# Altium schematic sheet temperatureSensor.SchDoc
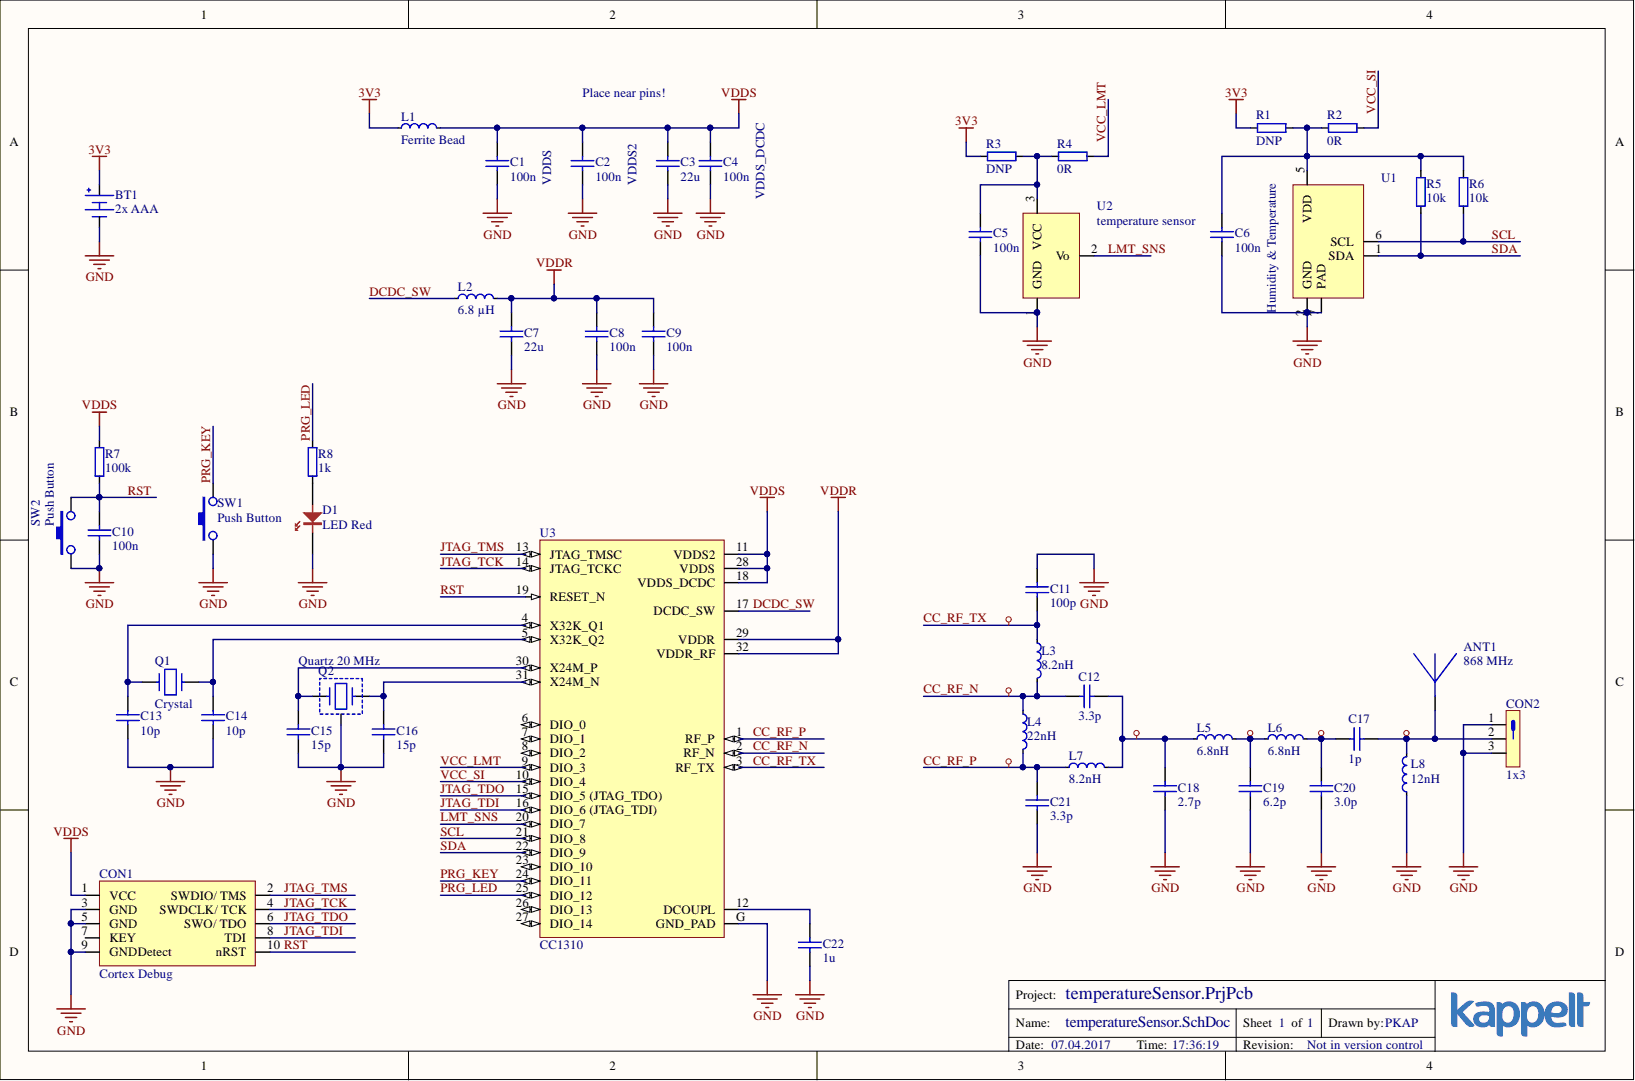
[diagram p0: temperatureSensor.SchDoc]

## Parts
ANT1  868 MHz  [Ant-868-Helical]  (no BOM)
BT1  2x AAA  [Keystone-2468]
C1  100n  [0603_N]
C2  100n  [0603_N]
C3  22u  [0805_N]
C4  100n  [0603_N]
C5  100n  [0603_N]
C6  100n  [0603_N]
C7  22u  [0805_N]
C8  100n  [0603_N]
C9  100n  [0603_N]
C10  100n  [0603_N]
C11  100p  [0603_N]
C12  3.3p  [0603_N]
C13  10p  [0603_N]
C14  10p  [0603_N]
C15  15p  [0805_N]
C16  15p  [0805_N]
C17  1p  [0603_N]
C18  2.7p  [0603_N]
C19  6.2p  [0603_N]
C20  3.0p  [0603_N]
C21  3.3p  [0603_N]
C22  1u  [0603_N]
CON1  Cortex Debug  [cortex-dbg]
CON2  1x3  [Header_254_1x3_male]
D1  LED Red  [0805_LED]
L1  Ferrite Bead  [0603_N]
L2  6.8 µH  [0805_N]
L3  8.2nH  [0603_N]
L4  22nH  [0603_N]
L5  6.8nH  [0603_N]
L6  6.8nH  [0603_N]
L7  8.2nH  [0603_N]
L8  12nH  [0603_N]
Q1  Crystal  [AB38t]
Q2  Quartz 20 MHz  [LFXTAL058124]
R1  DNP  [0603_N]
R2  0R  [0603_N]
R3  DNP  [0603_N]
R4  0R  [0603_N]
R5  10k  [0805_N]
R6  10k  [0805_N]
R7  100k  [0603_N]
R8  1k  [0603_N]
SW1  Push Button  [FSMSM]
SW2  Push Button  [FSMSM]
U1  Humidity & Temperature  [SI7020-DFN6]
U2  temperature sensor  [LMT85-TO92]
U3  CC1310  [CC1310F128RHB-QFN32]

## Nets
3V3: BT1.P L1.1 R1.1 R3.1
GND: BT1.N C1.1 C2.1 C3.1 C4.1 C5.1 C6.1 C7.1 C8.1 C9.1 C10.1 C11.2 C13.1 C14.1 C15.1 C16.1 C18.2 C19.2 C20.2 C21.1 C22.1 CON1.3(GND) CON1.5(GND) CON1.9(GNDDetect) CON2.1 CON2.3 D1.K L8.1 Q2.G SW1.1 SW2.1 U1.2(GND) U1.7(PAD) U2.1 U3.G(GND_PAD)
VDDR: C7.2 C8.2 C9.2 L2.2 U3.29(VDDR) U3.32(VDDR_RF)
VDDS: C1.2 C2.2 C3.2 C4.2 CON1.1(VCC) L1.2 R7.1 U3.11(VDDS2) U3.18(VDDS_DCDC) U3.28(VDDS)
CC_RF_N: C12.2 L3.2 L4.1 U3.2(RF_N)
CC_RF_P: C21.2 L4.2 L7.1 U3.1(RF_P)
CC_RF_TX: C11.1 L3.1 U3.3(RF_TX)
DCDC_SW: L2.1 U3.17(DCDC_SW)
JTAG_TCK: CON1.4(SWDCLK/_TCK) U3.14(JTAG_TCKC)
JTAG_TDI: CON1.8(TDI) U3.16(DIO_6_(JTAG_TDI))
JTAG_TDO: CON1.6(SWO/_TDO) U3.15(DIO_5_(JTAG_TDO))
JTAG_TMS: CON1.2(SWDIO/_TMS) U3.13(JTAG_TMSC)
LMT_SNS: U2.2 U3.20(DIO_7)
PRG_KEY: SW1.2 U3.24(DIO_11)
PRG_LED: R8.1 U3.25(DIO_12)
RST: C10.2 CON1.10(nRST) R7.2 SW2.2 U3.19(RESET_N)
SCL: R6.2 U1.6(SCL) U3.21(DIO_8)
SDA: R5.2 U1.1(SDA) U3.22(DIO_9)
VCC_LMT: R4.2 U3.9(DIO_3)
VCC_SI: R2.2 U3.10(DIO_4)
NetANT1_1: ANT1.1 C17.1 CON2.2 L8.2
NetC5_2: C5.2 R3.2 R4.1 U2.3
NetC6_2: C6.2 R1.2 R2.1 R5.1 R6.1 U1.5(VDD)
NetC12_1: C12.1 C18.1 L5.1 L7.2
NetC13_2: C13.2 Q1.1 U3.4(X32K_Q1)
NetC14_2: C14.2 Q1.2 U3.5(X32K_Q2)
NetC15_2: C15.2 Q2.Q2 U3.30(X24M_P)
NetC16_2: C16.2 Q2.Q1 U3.31(X24M_N)
NetC17_2: C17.2 C20.1 L6.2
NetC19_1: C19.1 L5.2 L6.1
NetC22_2: C22.2 U3.12(DCOUPL)
NetD1_A: D1.A R8.2

Not connected: CON1 7(KEY); U3 6(DIO_0) 7(DIO_1) 8(DIO_2) 23(DIO_10) 26(DIO_13) 27(DIO_14)

## Library data of the parts
ANT1 = ANT-868-Helical: 868 MHz Helical PCB Antenna, see TI swra416.pdf; Library Name: temperatureSensor.SchLib
BT1 = Battery-AAA-Double: Double AAA Battery Holder; MID: Keystone; PID: 2468; Component Kind: Standard; Library Name: temperatureSensor.SchLib; Supplier 1: Mouser; Supplier Part Number 1: 534-2468
C1 C2 C4-C6 C8-C10 = 100n_50V_0603: 100n 50V 0603; part_id: 1100026; accuracy: 10.00%; Database Table Name: capacitor_ceramic; MID: std; Category: _0603; Component Kind: Standard; Part Number: 100n_50V_0603; PID: std; Sch_Comment: 100n; Supplier 1: Mouser; Supplier Part Number 1: 710-885012206071; Value: 100n; voltage_rate: 50V; Library Name: kappelt_altlib.DbLib
C3 C7 = 22u_25V_0805: 22u 25V 0805; part_id: 1100136; accuracy: 10.00%; Database Table Name: capacitor_ceramic; MID: std; Category: _0805; Component Kind: Standard; Part Number: 22u_25V_0805; PID: std; Sch_Comment: 22u; Supplier 1: Mouser; Supplier Part Number 1: 81-GRM21BC80G226ME9L; Value: 22u; voltage_rate: 25V; Library Name: kappelt_altlib.DbLib
C11 = 100p_50V_0603: 100p 50V 0603; part_id: 1100011; accuracy: 10.00%; Database Table Name: capacitor_ceramic; MID: std; Category: _0603; Component Kind: Standard; Part Number: 100p_50V_0603; PID: std; Sch_Comment: 100p; Signal Integrity: C; Supplier 1: Mouser; Supplier Part Number 1: 710-885012206003; Value: 100p; voltage_rate: 50V; Library Name: kappelt_altlib.DbLib
C12 C21 = 3p3_50V_0603: 3p3 50V 0603; part_id: 1100003; accuracy: 10.00%; Database Table Name: capacitor_ceramic; MID: std; Category: _0603; Component Kind: Standard; Part Number: 3p3_50V_0603; PID: std; Sch_Comment: 3.3p; Signal Integrity: C; Supplier 1: Mouser; Supplier Part Number 1: 81-GRM1885C1H3R3CA1D; Value: 3.3p; voltage_rate: 50V; Library Name: kappelt_altlib.DbLib
C13 C14 = 10p_50V_0603: 10p 50V 0603; part_id: 1100006; accuracy: 10.00%; Database Table Name: capacitor_ceramic; MID: std; Category: _0603; Component Kind: Standard; Part Number: 10p_50V_0603; PID: std; Sch_Comment: 10p; Value: 10p; voltage_rate: 50V; Library Name: kappelt_altlib.DbLib
C15 C16 = 10p_50V_0805: 15p 50V 0805; Part Number: 15p_50V_0805; accuracy: 10.00%; Database Table Name: capacitor_ceramic; Category: _0805; Component Kind: Standard; Sch_Comment: 15p; Supplier 1: Mouser; Supplier Part Number 1: 710-885012007001; Value: 15p; voltage_rate: 50V; Library Name: kappelt_altlib.DbLib
C17 = 100p_50V_0603: 1p 50V 0603; Part Number: 1p_50V_0603; accuracy: 10.00%; Database Table Name: capacitor_ceramic; MID: std; Category: _0603; Component Kind: Standard; PID: std; Sch_Comment: 1p; Signal Integrity: C; Supplier 1: Mouser; Supplier Part Number 1: 609-251R14S1R0CV4T; Value: 1p; voltage_rate: 50V; Library Name: kappelt_altlib.DbLib
C18 = 3p3_50V_0603: 2p7 50V 0603; Part Number: 2p7_50V_0603; accuracy: 10.00%; Database Table Name: capacitor_ceramic; MID: std; Category: _0603; Component Kind: Standard; Sch_Comment: 2.7p; Signal Integrity: C; Supplier 1: Mouser; Supplier Part Number 1: 81-GRM1885C1H2R7CA1D; Value: 2.7p; voltage_rate: 50V; Library Name: kappelt_altlib.DbLib
C19 = 3p3_50V_0603: 6p2 50V 0603; Part Number: 6p2_50V_0603; accuracy: 10.00%; Database Table Name: capacitor_ceramic; MID: std; Category: _0603; Component Kind: Standard; Sch_Comment: 6.2p; Signal Integrity: C; Supplier 1: Mouser; Supplier Part Number 1: 581-06032U6R2BAT2A; Value: 6.2p; voltage_rate: 50V; Library Name: kappelt_altlib.DbLib
C20 = 3p3_50V_0603: 3p 50V 0603; Part Number: 3p_50V_0603; accuracy: 10.00%; Database Table Name: capacitor_ceramic; MID: std; Category: _0603; Component Kind: Standard; Sch_Comment: 3.0p; Signal Integrity: C; Supplier 1: Mouser; Supplier Part Number 1: 581-06035U3R0B; Value: 3.0p; voltage_rate: 50V; Library Name: kappelt_altlib.DbLib
C22 = 1u_50V_0603: 1u 50V 0603; part_id: 1100031; accuracy: 10.00%; Database Table Name: capacitor_ceramic; MID: std; Category: _0603; Component Kind: Standard; Part Number: 1u_50V_0603; PID: std; Sch_Comment: 1u; Supplier 1: Mouser; Supplier Part Number 1: 710-885012106010; Value: 1u; voltage_rate: 50V; Library Name: kappelt_altlib.DbLib
CON1 = Cortex-DBG: MID: Samtec; PID: FTSH-105-01-L-DV-K; Component Kind: Standard; Description: Cortex Debug; Library Name: temperatureSensor.SchLib
CON2 = Header_1x03_male: Male Connector 1x3; part_id: 1201103; Column Count: 3; current_rate: 2A; Database Table Name: con_header_254; MID: std; Pitch: 2.54mm; Row Count: 1; voltage_rate: 100V; Component Kind: Standard; Part Number: Header_1x03_male; PID: std; Pin Count: 3; Sch_Comment: 1x3; Library Name: kappelt_altlib.DbLib
D1 = LED-STD-RED-0805: Standard LED Red 0805; MID: std; Component Kind: Standard; Library Name: temperatureSensor.SchLib; PID: std; Supplier 1: Mouser; Supplier Part Number 1: 604-AP2012EC
L1 = Inductor_General_Purpose_0603: MID: Murata; PID: BLM18HE152SN1D; Component Kind: Standard; Description: Ferrite Bead; Library Name: temperatureSensor.SchLib; Sch_Comment: Ferrite Bead; Supplier 1: Mouser; Supplier Part Number 1: 81-BLM18HE152SN1D
L2 = Inductor_General_Purpose_0603: Chip Inductor 0805; MID: TDK; PID: MLZ2012N6R8LT000; Component Kind: Standard; Library Name: temperatureSensor.SchLib; Sch_Comment: 6.8 µH; Supplier 1: Mouser; Supplier Part Number 1: 810-MLZ2012N6R8L
L3 L7 = Inductor_General_Purpose_0603: 8.2nH Inductor; MID: Murata; PID: LQG15HS8N2J02D; Component Kind: Standard; Library Name: temperatureSensor.SchLib; Sch_Comment: 8.2nH; Signal Integrity: L; Supplier 1: Mouser; Supplier Part Number 1: 81-LQG15HS8N2J02D; Value: 8.2nH
L4 = Inductor_General_Purpose_0603: 22nH Inductor; MID: Murata; PID: LQG15HS22NJ02D; Component Kind: Standard; Library Name: temperatureSensor.SchLib; Sch_Comment: 22nH; Signal Integrity: L; Supplier 1: Mouser; Supplier Part Number 1: 81-LQG15HS22NJ02D; VALUE: 22nH
L5 L6 = Inductor_General_Purpose_0603: 6.8H Inductor; MID: Murata; PID: LQG15HS6N8J02D; Component Kind: Standard; Library Name: temperatureSensor.SchLib; Sch_Comment: 6.8nH; Signal Integrity: L; Supplier 1: Mouser; Supplier Part Number 1: 81-LQG15HS6N8J02D
  L5: Value: 6.8nH
  L6: VALUE: 6.8nH
L8 = Inductor_General_Purpose_0603: Inductor for PCB Antenna compensation; MID: TBD; Component Kind: Standard; Library Name: temperatureSensor.SchLib; PID: TBD; Sch_Comment: 12nH; Signal Integrity: L; Supplier 1: Mouser; Supplier Part Number 1: 609-L-14C12NJV4T; Value: 12nH
Q1 = AB38T-32.768KHZ: MID: Abracon; Component Kind: Standard; Description: AB38T-32.768KHZ; Library Name: temperatureSensor.SchLib; PID: AB38T-32.768KHZ; Supplier 1: Mouser; Supplier Part Number 1: 449-LFXTAL002996BULK
Q2 = LFXTAL058124: Standard 3.2 x 2.5mm SMD crystal in a ceramic package with a  seam sealed metal lid, hermetically sealed.; MID: IQD Frequency Products; Component Kind: Standard; Library Name: temperatureSensor.SchLib; PID: LFXTAL058124; Supplier 1: Mouser; Supplier Part Number 1: 449-LFXTAL058124REEL
R1 R3 = 0R_100mW_0603: 0R 100mW 0603; part_id: 1000001; accuracy: 5.00%; Database Table Name: resistor; voltage_rate: 50V; Category: _0603; Component Kind: Standard; Part Number: 0R_100mW_0603; power_rate: 100mW; Sch_Comment: DNP; Value: DNP; Library Name: kappelt_altlib.DbLib
R2 R4 = 0R_100mW_0603: 0R 100mW 0603; part_id: 1000001; accuracy: 5.00%; Database Table Name: resistor; MID: std; voltage_rate: 50V; Category: _0603; Component Kind: Standard; Part Number: 0R_100mW_0603; PID: std; power_rate: 100mW; Sch_Comment: 0R; Value: 0R; Library Name: kappelt_altlib.DbLib
R5 R6 = 10k_125mW_0805: 10k 125mW 0805; part_id: 1000150; accuracy: 5.00%; Database Table Name: resistor; MID: std; voltage_rate: 50V; Category: _0805; Component Kind: Standard; Part Number: 10k_125mW_0805; PID: std; power_rate: 125mW; Sch_Comment: 10k; Supplier 1: Mouser; Supplier Part Number 1: 588-AS08J1002ET; Value: 10k; Library Name: kappelt_altlib.DbLib
R7 = 100k_100mW_0603: 100k 100mW 0603; part_id: 1000062; accuracy: 5.00%; Database Table Name: resistor; MID: std; voltage_rate: 50V; Category: _0603; Component Kind: Standard; Part Number: 100k_100mW_0603; PID: std; power_rate: 100mW; Sch_Comment: 100k; Supplier 1: Mouser; Supplier Part Number 1: 71-RCA0603100KJNEC; Value: 100k; Library Name: kappelt_altlib.DbLib
R8 = 1k_100mW_0603: 1k 100mW 0603; part_id: 1000038; accuracy: 5.00%; Database Table Name: resistor; MID: std; voltage_rate: 50V; Category: _0603; Component Kind: Standard; Part Number: 1k_100mW_0603; PID: std; power_rate: 100mW; Sch_Comment: 1k; Supplier 1: Mouser; Supplier Part Number 1: 71-CRCW0603-1.0K-E3; Value: 1k; Library Name: kappelt_altlib.DbLib
SW1 SW2 = Switch-FSMSM: Taster, Nicht beleuchtet, 24 V, 50 mA, 180 gf, Baureihe FSMSM, AlcoSwitch; MID: TE Connectivity; Component Kind: Standard; Farnell_ID: 1703878; Library Name: temperatureSensor.SchLib; PID: FSMSM; Supplier 1: Mouser; Supplier Part Number 1: 506-FSMSM
U1 = SI7020: I2C HUMIDITY AND TEMPERATURE SENSOR; MID: Silicon Labs; Component Kind: Standard; Library Name: temperatureSensor.SchLib; PID: SI7020; Supplier 1: Mouser; Supplier Part Number 1: 634-SI7020-A20-GMR
U2 = LMT85-TO92: Analog Temperature Sensors with Class-AB Output; MID: Texas Instruments; PID: LMT85LP; Component Kind: Standard; Library Name: temperatureSensor.SchLib
U3 = CC1310F128RHB: CC1310 SimpleLink™ Ultra-Low-Power Sub-1 GHz Wireless MCU; MID: Texas Instruments; PID: CC1310F128RHB/ CC1310F64RHB/ CC1310F32RHB (different flash sizes); Component Kind: Standard; Library Name: temperatureSensor.SchLib; Supplier 1: Mouser; Supplier Part Number 1: 595-CC1310F32RHBT
